# Altium schematic sheet GD-Link.SchDoc(GD-Link)
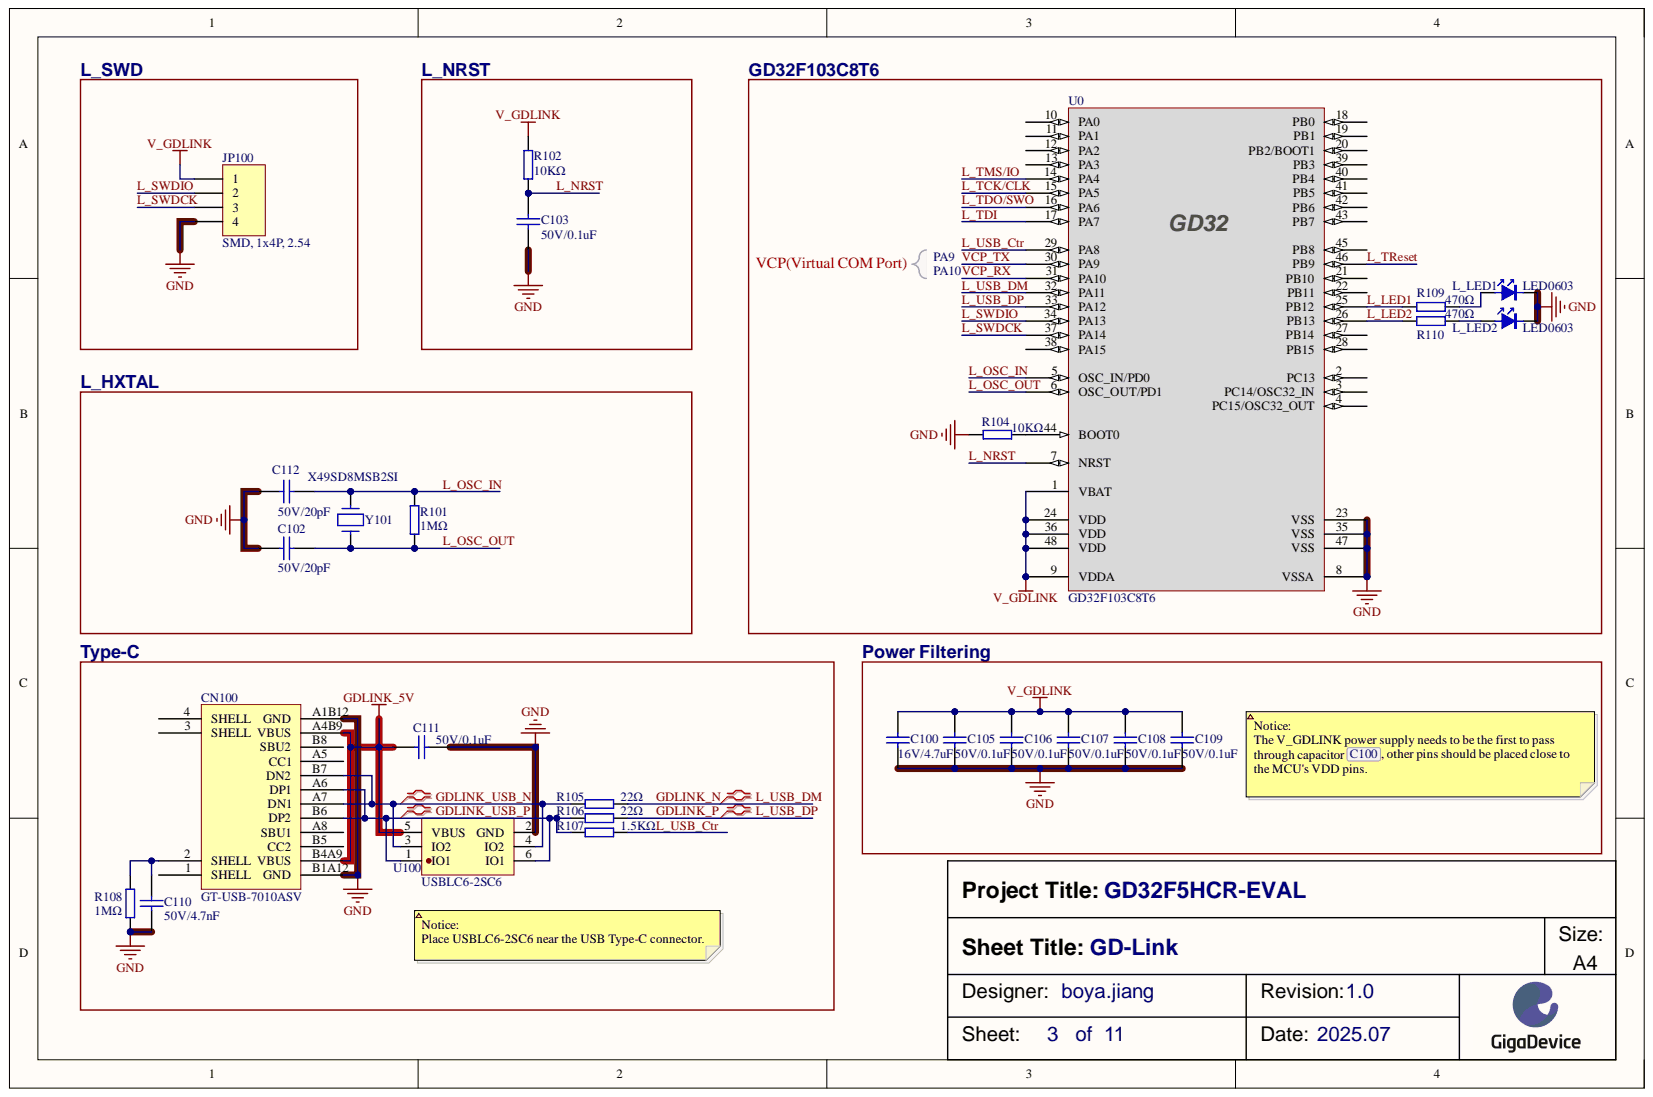
[diagram p0: GD-Link.SchDoc]

## Parts
C100  16V/4.7uF  [0603-C]
C102  50V/20pF  [0603-C]
C103  50V/0.1uF  [0603-C]
C105  50V/0.1uF  [0603-C]
C106  50V/0.1uF  [0603-C]
C107  50V/0.1uF  [0603-C]
C108  50V/0.1uF  [0603-C]
C109  50V/0.1uF  [0603-C]
C110  50V/4.7nF  [0603-C]
C111  50V/0.1uF  [0603-C]
C112  50V/20pF  [0603-C]
CN100  GT-USB-7010ASV  [USB-C-16Pin_GT-USB-7010ASV]
JP100  SMD, 1x4P, 2.54  [SMD-SWD]  (no BOM)
L_LED1  NCD0603C1(黄绿)  [0603-LED-Green]  (value not drawn)
L_LED2  NCD0603C1(黄绿)  [0603-LED-Green]  (value not drawn)
R101  1MΩ  [0603-R]
R102  10KΩ  [0603-R]
R104  10KΩ  [0603-R]
R105  22Ω  [0603-R]
R106  22Ω  [0603-R]
R107  1.5KΩ  [0603-R]
R108  1MΩ  [0603-R]
R109  470Ω  [0603-R]
R110  470Ω  [0603-R]
U0  GD32F103C8T6  [LQFP48_7x7x1.6]
U100  USBLC6-2SC6  [SOT-23-6]
Y101  X49SD8MSB2SI  [HC-49S]

## Nets
GDLINK_5V: C111.1 CN100.A4B9(VBUS) CN100.B4A9(VBUS) U100.5(VBUS)
GND: C100.1 C102.2 C103.2 C105.1 C106.1 C107.1 C108.1 C109.1 C110.1 C111.2 C112.1 CN100.A1B12(GND) CN100.B1A12(GND) JP100.4 L_LED1.K L_LED2.K R104.1 R108.1 U0.8(VSSA) U0.23(VSS) U0.35(VSS) U0.47(VSS) U100.2(GND)
V_GDLINK: C100.2 C105.2 C106.2 C107.2 C108.2 C109.2 JP100.1 R102.2 U0.1(VBAT) U0.9(VDDA) U0.24(VDD) U0.36(VDD) U0.48(VDD)
GDLINK_N: R105.1 U0.32(PA11)
GDLINK_P: R106.1 U0.33(PA12)
GDLINK_USB_N: CN100.A7(DN1) CN100.B7(DN2) R105.2 U100.3(IO2) U100.4(IO2)
GDLINK_USB_P: CN100.A6(DP1) CN100.B6(DP2) R106.2 R107.2 U100.1(IO1) U100.6(IO1)
L_LED1: R109.1 U0.25(PB12)
L_LED2: R110.1 U0.26(PB13)
L_NRST: C103.1 R102.1 U0.7(NRST)
L_OSC_IN: C112.2 R101.2 U0.5(OSC_IN/PD0) Y101.2
L_OSC_OUT: C102.1 R101.1 U0.6(OSC_OUT/PD1) Y101.1
L_SWDCK: JP100.3 U0.37(PA14)
L_SWDIO: JP100.2 U0.34(PA13)
L_TCK/CLK: U0.15(PA5)
L_TDI: U0.17(PA7)
L_TDO/SWO: U0.16(PA6)
L_TMS/IO: U0.14(PA4)
L_TReset: U0.46(PB9)
L_USB_Ctr: R107.1 U0.29(PA8)
VCP_RX: U0.31(PA10)
VCP_TX: U0.30(PA9)
NetC110_2: C110.2 CN100.2(SHELL) R108.2
NetL_LED1_A: L_LED1.A R109.2
NetL_LED2_A: L_LED2.A R110.2
NetR104_2: R104.2 U0.44(BOOT0)

Not connected: CN100 1(SHELL) 3(SHELL) 4(SHELL) A5(CC1) A8(SBU1) B5(CC2) B8(SBU2); U0 2(PC13) 3(PC14/OSC32_IN) 4(PC15/OSC32_OUT) 10(PA0) 11(PA1) 12(PA2) 13(PA3) 18(PB0) 19(PB1) 20(PB2/BOOT1) 21(PB10) 22(PB11) 27(PB14) 28(PB15) 38(PA15) 39(PB3) 40(PB4) 41(PB5) 42(PB6) 43(PB7) 45(PB8)

## Library data of the parts
C100 = 50V/0.1uF: 表贴电容, X7R; Component Kind: Standard; Library Name: KLTC-电容.SchLib
C102 C112 = 50V/20pF: 表贴电容; Component Kind: Standard; Library Name: KLTC-电容.SchLib
C103 C105-C109 C111 = 50V/0.1uF: 表贴电容; Component Kind: Standard; Library Name: KLTC-电容.SchLib
C110 = 50V/4700pF: 表贴电容; Component Kind: Standard; Library Name: KLTC-电容.SchLib
CN100 = USB-C: 表贴USB2.0 TYPE-C接口，16PIN; Component Kind: Standard; Library Name: boyajiang.SchLib
JP100 = HEADER 4: 表贴排针; Library Name: KLTC-按键及接插件.SchLib
L_LED1 L_LED2 = LED0603: 表贴LED发光管; Component Kind: Standard; Footprint For: LED0603; Library Name: xufei.SchLib
R101 R108 = 1MΩ: 表贴电阻; Component Kind: Standard; Library Name: KLTC-电阻.SchLib
R102 R104 = 10KΩ: 表贴电阻; Component Kind: Standard; Library Name: KLTC-电阻.SchLib
R105 R106 R109 R110 = 1KΩ: 表贴电阻; Component Kind: Standard; Library Name: KLTC-电阻.SchLib
R107 = 1.5KΩ: 表贴电阻; Component Kind: Standard; Library Name: KLTC-电阻.SchLib
U0 = GD32F103CxTx: 表贴MCU; Database Table Name: GD-MCU; Note: GD供料; Component Kind: Standard; ID: GD32F103CxTx; Library Name: SVNDatabase.SVNDbLib
U100 = USBLC6-2SC6: 低结电容TVS阵列，可用于USB2.0接口防护; Note: 不焊; Component Kind: Standard; Library Name: boyajiang.SchLib
Y101 = X49SD8MSB2SI: 直插晶振, 8MHz, 20pF, HC-49S; Component Kind: Standard; Library Name: boyajiang.SchLib
